# Altium schematic sheet 01 - BMS.SchDoc
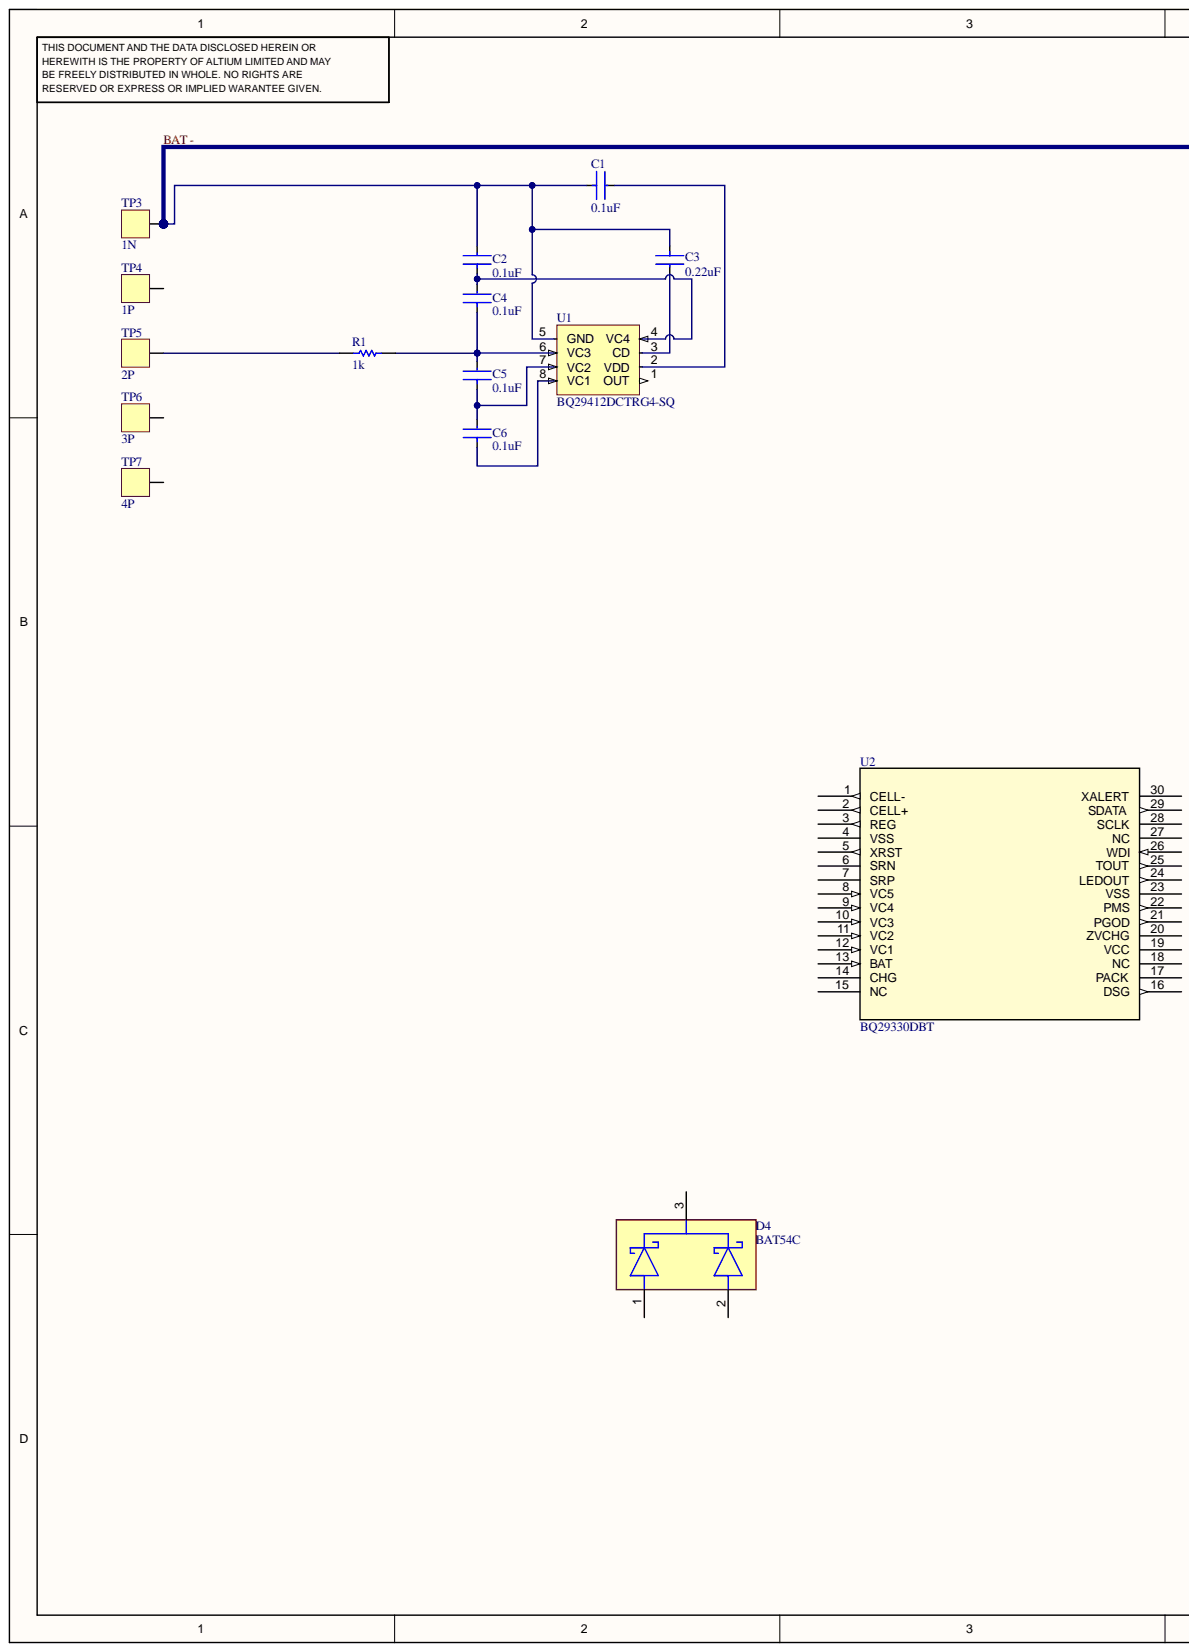
[diagram p0: 01 - BMS.SchDoc, left]
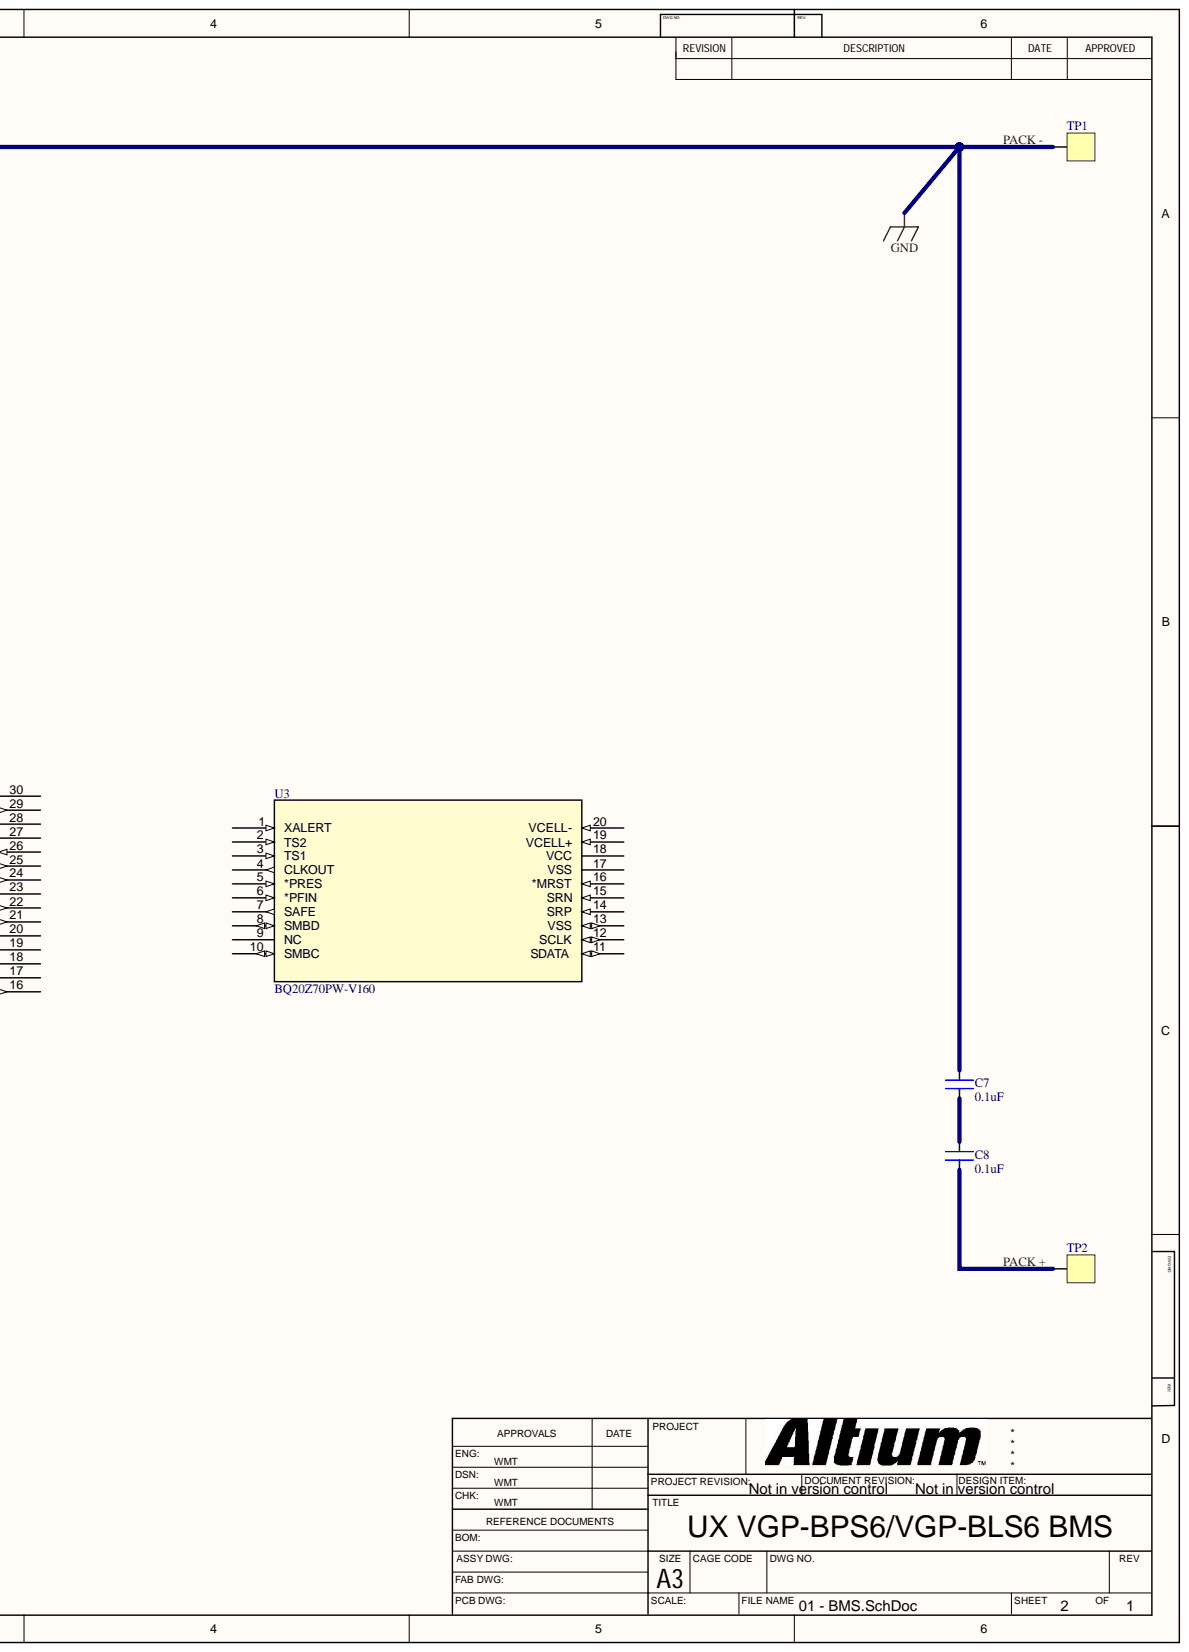
[diagram p1: 01 - BMS.SchDoc, right]

## Parts
C1  0.1uF  [FP-GRM033-0_03-IPC_C]
C2  0.1uF  [FP-GRM033-0_03-IPC_C]
C3  0.22uF  [FP-CL03-IPC_C]
C4  0.1uF  [FP-GRM033-0_03-IPC_C]
C5  0.1uF  [FP-GRM033-0_03-IPC_C]
C6  0.1uF  [FP-GRM033-0_03-IPC_C]
C7  0.1uF  [FP-GRM033-0_03-IPC_C]
C8  0.1uF  [FP-GRM033-0_03-IPC_C]
D4  BAT54C  [FP-MA03D-MFG]
R1  1k  [RESC0603X26X15LL03T05]
TP1  Pad  [PAD_SQ_2MM]  (value not drawn)  (no BOM)
TP2  Pad  [PAD_SQ_2MM]  (value not drawn)  (no BOM)
TP3  1N  [PAD_SQ_2MM]  (no BOM)
TP4  1P  [PAD_SQ_2MM]  (no BOM)
TP5  2P  [PAD_SQ_2MM]  (no BOM)
TP6  3P  [PAD_SQ_2MM]  (no BOM)
TP7  4P  [PAD_SQ_2MM]  (no BOM)
U1  BQ29412DCTRG4-SQ  [DCT8]
U2  BQ29330DBT  [DBT30]
U3  BQ20Z70PW-V160  [PW20]

## Nets
BAT -: C1.1 C2.2 C3.1 C7.2 TP1.1 TP3.1 U1.5(GND)
PACK +: C8.1 TP2.1
NetC1_2: C1.2 U1.2(VDD)
NetC2_1: C2.1 C4.2 U1.4(VC4)
NetC3_2: C3.2 U1.3(CD)
NetC4_1: C4.1 C5.2 R1.2 U1.6(VC3)
NetC5_1: C5.1 C6.2 U1.7(VC2)
NetC6_1: C6.1 U1.8(VC1)
NetC7_1: C7.1 C8.2
NetR1_1: R1.1 TP5.1

Not connected: D4 1 2 3; TP4 1; TP6 1; TP7 1; U1 1(OUT); U2 1(CELL-) 2(CELL+) 3(REG) 4(VSS) 5(XRST) 6(SRN) 7(SRP) 8(VC5) 9(VC4) 10(VC3) 11(VC2) 12(VC1) 13(BAT) 14(CHG) 15(NC) 16(DSG) 17(PACK) 18(NC) 19(VCC) 20(ZVCHG) 21(PGOD) 22(PMS) 23(VSS) 24(LEDOUT) 25(TOUT) 26(WDI) 27(NC) 28(SCLK) 29(SDATA) 30(XALERT); U3 1(XALERT) 2(TS2) 3(TS1) 4(CLKOUT) 5(*PRES) 6(*PFIN) 7(SAFE) 8(SMBD) 9(NC) 10(SMBC) 11(SDATA) 12(SCLK) 13(VSS) 14(SRP) 15(SRN) 16(*MRST) 17(VSS) 18(VCC) 19(VCELL+) 20(VCELL-)

## Library data of the parts
C1 C2 C4-C8 = CMP-2010-01264-2: Chip Multilayer Ceramic Capacitors for General Purpose, 0201, 0.10uF, X5R, 15%, 10%, 10V; Capacitance: 100nF; Case Code (Imperial): 0201; Case Code (Metric): 0603; Case/Package: 0201; Component Kind: Standard; Depth: 0.3mm; Dielectric: X5R; Height: 0.3mm; Lead Free: Lead Free; Length: 0.6mm; Library Name: Altium Content Vault; Max Operating Temperature: 85°C; Min Operating Temperature: -55°C; Mount: Surface Mount; Package Quantity: 1; Packaging: Tape and Reel; Radiation Hardening: No; REACH SVHC: No SVHC; Termination: SMD/SMT; Thickness: 0.013inch; Tolerance: 10%; Voltage: 10V; Voltage Rating: 10V; Voltage Rating (DC): 10V; Weight: 6E-06oz; Width: 0.012inch
C3 = CMP-13271-002367-1: Cap Ceramic 220nF 10V X5R ±10% Pad SMD 0201 +85°C T/R; Capacitance: 220nF; Case Code (Imperial): 0201; Case Code (Metric): 0603; Case/Package: 0201; Component Kind: Standard; Depth: 0.3mm; Dielectric: X5R; Lead Free: Lead Free; Length: 0.6mm; Library Name: Altium Content Vault; Max Operating Temperature: 85°C; Min Operating Temperature: -55°C; Mount: Surface Mount; Package Quantity: 1; Packaging: Tape and Reel; Radiation Hardening: No; Thickness: 0.013inch; Tolerance: 10%; Voltage Rating: 10V; Voltage Rating (DC): 10V; Width: 0.012inch
D4 = CMP-07162-000010-1: Diode Array 1 Pair Common Cathode Schottky 30V 200mA Surface Mount TO-236-3, SC-59, SOT-23-3; Manufacturer: ON Semiconductor; Obsolete Part: true; Capacitance: 10pF; Max Junction Temp: +150°C; Max Surge Forward Current: 600mA; Peak Reverse Current: 2uA; Standoff Height: 0mm; Value: 10pF; Automotive: No; Category: Diode; Component Kind: Standard; ComponentLink2Description: Datasheet; ComponentLink2URL: https://media.digikey.com/pdf/Data%20Sheets/ON%20Semiconductor%20PDFs/BAT54(A,C,S).pdf; Device Class L1: Discrete Semiconductors; Device Class L2: Diodes; Device Class L3: Schottky Diodes; Digikey Description: DIODE ARRAY SCHOTTKY 30V SOT23-3; Forward Current: 200mA; Height: 1.2mm; Library Name: Altium Content Vault; Manufacturer Part Number: BAT54C; Max Repetitive Reverse Voltage: 30V; Octopart: https://octopart.com/bat54c-on+semiconductor-8095621; Package: MA03D; Reverse Voltage: 30V; Temperature: -55°C to +150°C; Temperature Range High: +150°C; Temperature Range Low: -55°C
R1 = CMP-2004-03624-1: Manufacturer: TE Connectivity / AMP; Part Number: CRG0201F1K0; Component Kind: Standard; Library Name: Altium Content Vault
TP1-TP7 = PAD_SQ_2MM: Test Point Square 2mm; Library Name: Custom Schematic Library.SchLib
U2 = BQ29330DBT: No Description Available; Mfr_Name: Texas Instruments; Component Kind: Standard; Library Name: BQ29330DBT.SchLib; RefDes: RefDes; Type: Type
U3 = BQ20Z70PW-V160: No Description Available; Mfr_Name: Texas Instruments; Component Kind: Standard; Library Name: BQ20Z70PW-V160.SchLib; RefDes: RefDes; Type: Type
U1 = BQ29412DCTRG4-SQ: Component Kind: Standard; Library Name: BQ29412DCTRG4.SchLib
